# Altium schematic sheet Sheet1.SchDoc(Sheet1)
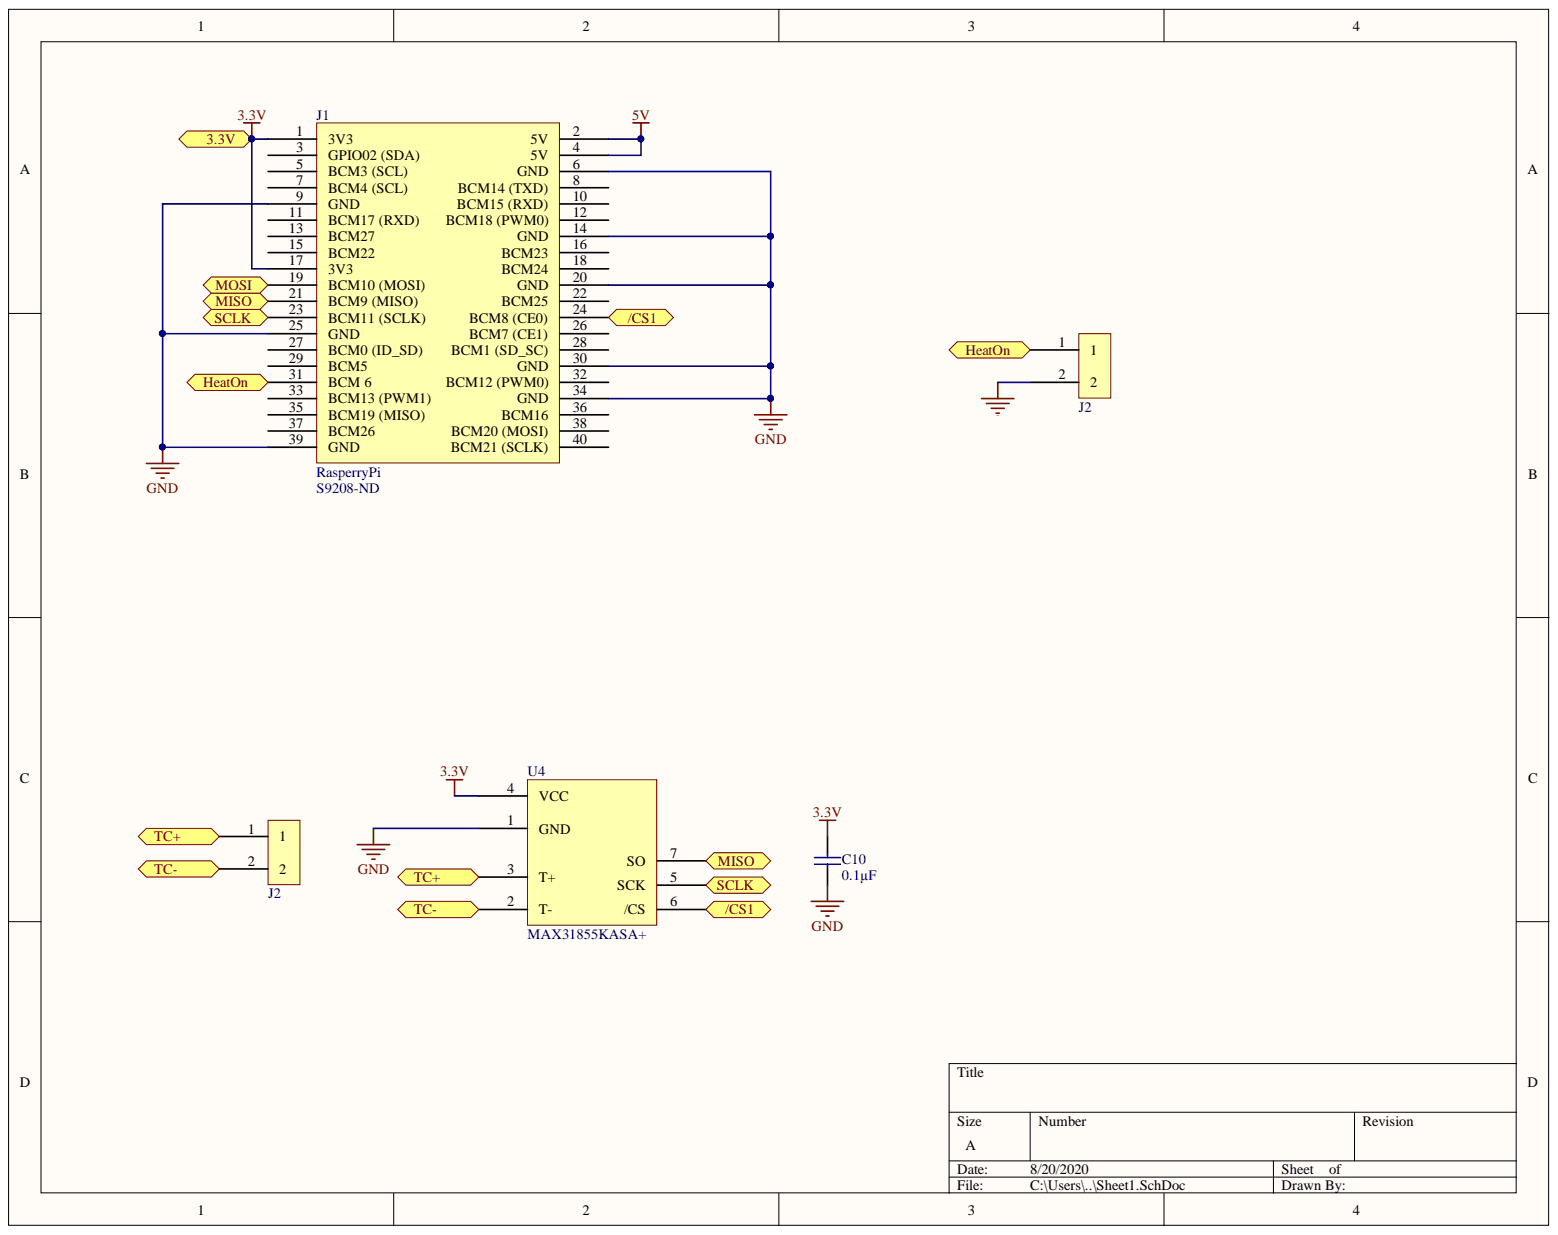
[diagram p0: Sheet1.SchDoc]

## Parts
C10  Capacitance: 0.1µF  [CAPC1608X09N - Kemet Ceramic 0603 CJ]  (hidden comment: C0603C104K5RACTU)
J1  RasperryPi  Supplier Part Number 1: S9208-ND  [PREC020DAAN-RC]
J2  282836-2  [282836-2]  (value not drawn)
J2  282836-2  [282836-2]  (value not drawn)
U4  MAX31855  [MAX31855KASA+]

## Ports
3.3V, /CS1, HEATON, MISO, MOSI, SCLK, TC+, TC-

## Nets
5V: J1.2(5V) J1.4(5V)
GND: C10.1 J1.6(GND) J1.9(GND) J1.14(GND) J1.20(GND) J1.25(GND) J1.30(GND) J1.34(GND) J1.39(GND) J2.2 U4.1(GND)
3.3V: C10.2 J1.1(3V3) J1.17(3V3) U4.4(VCC) ; port 3.3V
/CS1: J1.24(BCM8_(CE0)) U4.6(/CS) ; port /CS1
MISO: J1.21(BCM9_(MISO)) U4.7(SO) ; port MISO
MOSI: J1.19(BCM10_(MOSI)) ; port MOSI
SCLK: J1.23(BCM11_(SCLK)) U4.5(SCK) ; port SCLK
NetJ1_31: J1.31(BCM_6) J2.1 ; port HEATON
NetJ2_1: J2.1 U4.3(T+)
NetJ2_2: J2.2 U4.2(T-)

Not connected: J1 3(GPIO02_(SDA)) 5(BCM3_(SCL)) 7(BCM4_(SCL)) 8(BCM14_(TXD)) 10(BCM15_(RXD)) 11(BCM17_(RXD)) 12(BCM18_(PWM0)) 13(BCM27) 15(BCM22) 16(BCM23) 18(BCM24) 22(BCM25) 26(BCM7_(CE1)) 27(BCM0_(ID_SD)) 28(BCM1_(SD_SC)) 29(BCM5) 32(BCM12_(PWM0)) 33(BCM13_(PWM1)) 35(BCM19_(MISO)) 36(BCM16) 37(BCM26) 38(BCM20_(MOSI)) 40(BCM21_(SCLK))

## Library data of the parts
C10 = C0603C104K5RACTU: CAP CER 0.1UF 50V X7R 0603; Manufacturer: KEMET; Tolerance: ±10%; Applications: General Purpose; Category: Capacitors; Component Kind: Standard; Failure Rate: -; Features: -; Height - Seated (Max): -; Lead Spacing: -; Lead Style: -; Library Name: Capacitors.SCHLIB; Manufacturer Part Number: C0603C104K5RACTU; Mounting Type: Surface Mount, MLCC; Operating Temperature: -55°C ~ 125°C; Package / Case: 0603 (1608 Metric); Packaging: Cut Tape (CT); Ratings: -; RoHS: RoHS Compliant; Size / Dimension: 0.063" L x 0.031" W (1.60mm x 0.80mm); Supplier 1: Digi-Key; Supplier Part Number 1: 399-5089-1-ND; Temperature Coefficient: X7R; Thickness (Max): 0.034" (0.87mm); Voltage - Rated: 50V
J2 J2 = 282836-2: TERM BLOCK 2POS SIDE ENTRY 5MM; Manufacturer: TE Connectivity AMP Connectors; Clamp Material - Plating: Brass - Nickel Plated; Current: 13.5A; Mating Orientation: Horizontal with Board; Number of Levels: 1; Pitch: 0.197" (5.00mm); Positions Per Level: 2; Screw Thread: M3; Torque - Screw: 0.68 Nm (6 Lb-In); Voltage: 300V; Wire Gauge: 16-30 AWG; Wire Termination: Screw - Rising Cage Clamp; Category: Connectors, Interconnects; Color: Green; Component Kind: Standard; Contact Material - Plating: Brass - Tin Plated; Features: Interlocking (Side); Housing Material: Polyamide (PA66), Nylon 6/6; Library Name: Connectors.SCHLIB; Manufacturer Part Number: 282836-2; Mounting Type: Through Hole; Operating Temperature: -; Packaging: Tray; RoHS: RoHS Compliant; Screw Material - Plating: Brass - Nickel Plated; Supplier 1: Digi-Key; Supplier Part Number 1: A98076-ND
U4 = MAX31855: IC CONV THERMOCOUPLE-DGTL SOIC Type K SPI; Manufacturer: Maxim Integrated; Current - Supply: 900µA; Input Type: Thermocouple (Multiple); Category: Integrated Circuits (ICs); Component Kind: Standard; Interface: SPI; Manufacturer Part Number: MAX31855KASA+; Mounting Type: Surface Mount; Operating Temperature: -40°C ~ 125°C; Package / Case: 8-SOIC (0.154", 3.90mm Width); Supplier 1: Digi-Key; Supplier Part Number 1: MAX31855KASA+-ND; Type: Thermocouple to Digital Converter; Library Name: Thermal Managment.SCHLIB
J1 = RasperryPi: Component Kind: Standard; Description: RasperryPi; Library Name: Connectors.SCHLIB; Supplier 1: Digi-Key
